# Altium schematic sheet Timer_copy.SchDoc
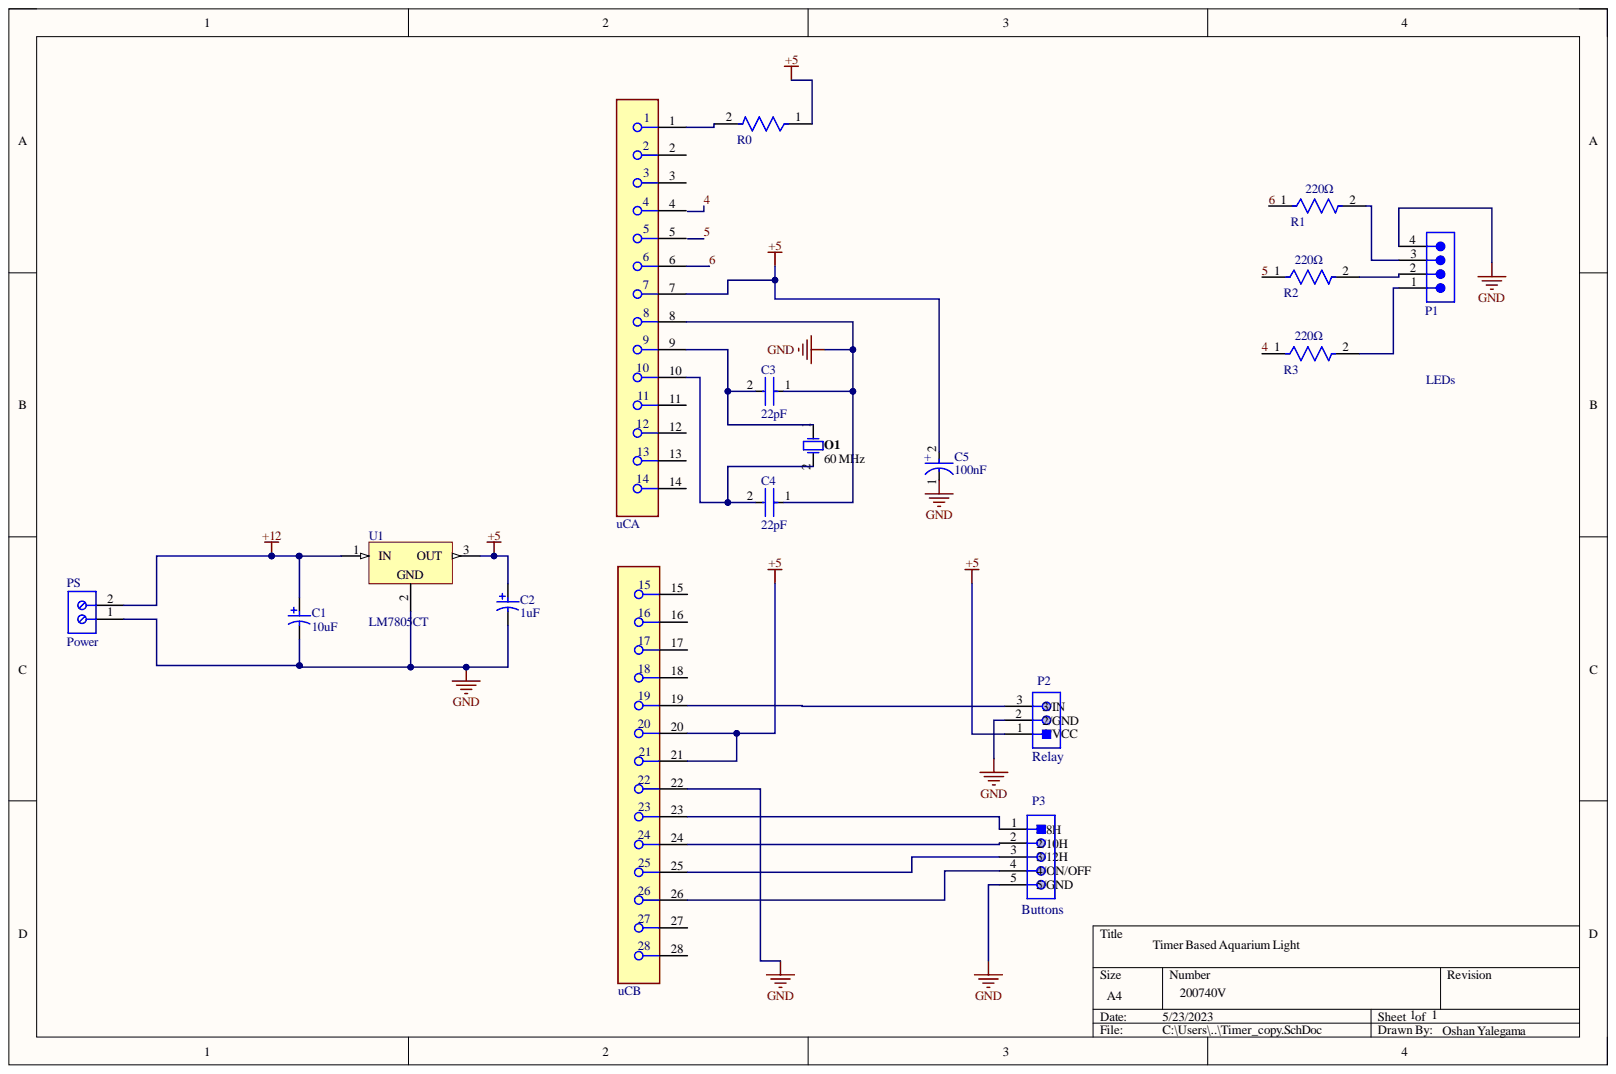
[diagram p0: Timer_copy.SchDoc]

## Parts
C1  10uF  [CAPPR55-200-1100X500X1200]
C2  1uF  [CAPPR55-200-1100X500X1200]
C3  22pF  [FP-RCE0DB-MFG]
C4  22pF  [FP-RCE0DB-MFG]
C5  100nF  [FP-RAD-TH-D_5_0_5-L_11_1-MFG]
O1  60 MHz  [FOX-FOXSLF160-20]
P1  LEDs  [SMTC-TSW-104-08-X-S]
P2  Relay  [61900311121]
P3  Buttons  [61300511121]
PS  Power  [691102710002]
R0  CF18JT2K20  [FP-CF18-MFG]  (value not drawn)
R1  value 220Ω  Resistance: 220Ω  [FP-CF18-MFG]  (hidden comment: CF18JT2K20)
R2  value 220Ω  [FP-CF18-MFG]  (hidden comment: CF18JT2K20)
R3  value 220Ω  [FP-CF18-MFG]  (hidden comment: CF18JT2K20)
U1  LM7805CT  [FAIR-TO-220-3]
uC  1-2199298-9  [FP-1-2199298-9-MFG]  (value not drawn)  (parts A,B)

## Nets
+12: C1.1 PS.2 U1.1
GND: C1.2 C2.2 C3.1 C4.1 C5.1 P1.4 P2.2 P3.5 PS.1 U1.2 uC.8 uC.22
4: R3.1
5: C2.1 C5.2 P2.1 R0.1 R2.1 U1.3 uC.7 uC.20 uC.21
6: R1.1 uC.6
NetC3_2: C3.2 O1.1 uC.9
NetC4_2: C4.2 O1.2 uC.10
NetP1_1: P1.1 R3.2
NetP1_2: P1.2 R2.2
NetP1_3: P1.3 R1.2
NetP2_3: P2.3 uC.19
NetP3_1: P3.1 uC.23
NetP3_2: P3.2 uC.24
NetP3_3: P3.3 uC.25
NetP3_4: P3.4 uC.26
NetR0_2: R0.2 uC.1

Not connected: uC 2 3 4 5 11 12 13 14 15 16 17 18 27 28

## Library data of the parts
C1 = CMP-1665-00038-2: Aluminum Electrolytic Capacitor, 10 uF, +/- 20%, 50 V, -40 to 85 degC, 2-Pin THD, RoHS, Bulk; Manufacturer: Panasonic; Dielectric Material: Aluminium; Dissipation Factor: 0.12%; Lead Diameter: 0.5mm; Lead/Base Style: Straight; Leakage Current: 3uA; Life (Hours): 2000Hour; Ripple Current: 65mA; Voltage: 50V; Capacitance: 10uF; Case/Package: Radial; Component Kind: Standard; Datasheet URL: https://industrial.panasonic.com/cdbs/www-data/pdf/RDF0000/ABA0000C1218.pdf; Datasheet Version: Rev. 05, 10/2016; Diameter: 5mm; Height: 11mm; Height - Seated (Max): 0.472inch; Lead Free: LeadFree; Lead Pitch: 2mm; Length: 11mm; Library Name: Altium Content Vault; Manufacturer URL: http://www.panasonic.com/; Max Operating Temperature: 85degC; Min Operating Temperature: -40degC; Mount: ThroughHole; Number of Pins: 2; Package Description: 2-Pin Radial Lead, Dia 5 mm, Height 12 mm; Package Quantity: 200; Package Reference: CAPPR55-200-1100X500X1200; Packaging: Bulk; Radiation Hardening: No; REACH SVHC: NoSVHC; Ripple Current (AC): 65mA; RoHSCompliant: true; Termination: Radial; Tolerance: 20%; Voltage Rating: 50V; Voltage Rating (DC): 50V
C2 = CMP-1665-00038-2: Aluminum Electrolytic Capacitor, 10 uF, +/- 20%, 50 V, -40 to 85 degC, 2-Pin THD, RoHS, Bulk; Manufacturer: Panasonic; Capacitance: 10uF; Dielectric Material: Aluminium; Dissipation Factor: 0.12%; Lead Diameter: 0.5mm; Lead/Base Style: Straight; Leakage Current: 3uA; Life (Hours): 2000Hour; Ripple Current: 65mA; Voltage: 50V; Case/Package: Radial; Component Kind: Standard; Datasheet URL: https://industrial.panasonic.com/cdbs/www-data/pdf/RDF0000/ABA0000C1218.pdf; Datasheet Version: Rev. 05, 10/2016; Diameter: 5mm; Height: 11mm; Height - Seated (Max): 0.472inch; Lead Free: LeadFree; Lead Pitch: 2mm; Length: 11mm; Library Name: Altium Content Vault; Manufacturer URL: http://www.panasonic.com/; Max Operating Temperature: 85degC; Min Operating Temperature: -40degC; Mount: ThroughHole; Number of Pins: 2; Package Description: 2-Pin Radial Lead, Dia 5 mm, Height 12 mm; Package Quantity: 200; Package Reference: CAPPR55-200-1100X500X1200; Packaging: Bulk; Radiation Hardening: No; REACH SVHC: NoSVHC; Ripple Current (AC): 65mA; RoHSCompliant: true; Termination: Radial; Tolerance: 20%; Voltage Rating: 50V; Voltage Rating (DC): 50V
C3 C4 = CMP-06035-047347-1: Cap Ceramic 22pF 100V C0G ±5% Radial 2.5mm +125°C Ammo Pack; Lead Diameter: 0.5mm; Lead/Base Style: Straight; Capacitance: 22pF; Case/Package: Radial; Component Kind: Standard; Depth: 2.5mm; Dielectric: C0G; Height: 3.5mm; Height - Seated (Max): 0.138inch; Lead Pitch: 0.098inch; Length: 3.6mm; Library Name: Altium Content Vault; Max Operating Temperature: 125°C; Min Operating Temperature: -55°C; Mount: Through Hole; Package Quantity: 2000; Packaging: Tape and Reel; Ratings: AEC-Q200; Resistor Type: Ceramic; Termination: Radial; Tolerance: 5%; Voltage: 100V; Voltage Rating: 100V; Voltage Rating (DC): 100V; Width: 0.098inch
C5 = CMP-05427-001672-1: Aluminum Electrolytic Capacitors (Radial Lead Type) 68uF ±20% 16V; ESR (Equivalent Series Resistance): 300mR; Lead Diameter: 0.5mm; Life (Hours): 5000Hour; Polarity: Polar; Ripple Current: 280mA; Capacitance: 68uF; Case/Package: Radial; Component Kind: Standard; Diameter: 5mm; Features: General Purpose; Height: 11mm; Height - Seated (Max): 0.433inch; Impedance: 300mR; Lead Pitch: 5mm; Length: 11mm; Library Name: Altium Content Vault; Max Operating Temperature: 105°C; Min Operating Temperature: -40°C; Mount: Through Hole; Package Quantity: 2000; Packaging: Tape and Reel; Radiation Hardening: No; REACH SVHC: No SVHC; RoHS Compliant: Yes; Series: FR; Termination: Radial; Tolerance: 20%; Voltage Rating: 16V; Voltage Rating (DC): 16V
O1 = CMP-1749-00003-1: Resistance Weld Thru-Hole Crystal, 16 MHz, -20 to 70 degC, 2-Pin THD, RoHS, Bulk; Case\Package: Axial; Power Rating: 250 mW; Resistance: 221 kohm; Tolerance: 1%; Voltage Rating: 250 V; Component Kind: Standard; ComponentLink1Description: Manufacturer URL; ComponentLink1URL: http://www.foxonline.com/; ComponentLink2Description: Datasheet; ComponentLink2URL: http://www.foxonline.com/pdfs/hc49slf.pdf; DatasheetVersion: Mar-15; Length: 0.248 inch; Library Name: Altium Content Vault; Max Operating Temperature: 155 degC; Min Operating Temperature: -55 degC; Mount: Through Hole; Package Quantity: 200; PackageDescription: 2-Pin Through Hole Device, Body 11.35 x 4.65 mm; PackageReference: FOXSLF160-20; Packaging: Bulk; RoHSCompliant: true
P1 = CMP-1024-00357-1: 0.025" SQ Post Header, Through-hole, Vertical, -55 to 125 degC, 2.54 mm Pitch, 4-Pin, Male, RoHS; Manufacturer: Samtec; PartNumber: TSW-104-08-G-S; Pitch: 2.54 mm; Component Kind: Standard; ComponentLink1Description: Manufacturer URL; ComponentLink1URL: http://www.samtec.com; ComponentLink2Description: Datasheet; ComponentLink2URL: http://www.samtec.com/documents/webfiles/pdf/TSW_TH.PDF; ComponentLink3Description: Package Specification; ComponentLink3URL: http://www.samtec.com/documents/webfiles/cpdf/TSW-XXX-XX-XXX-X-XX-XXX-MKT.pdf; DatasheetVersion: F-214; Library Name: Altium Content Vault; Mounting Technology: Through-hole; Orientation: Vertical; PackageDescription: .025[0.64] SQ. Post Terminal Strip Assembly; PackageReference: TSW-104-08-X-S; PackageVersion: DM, 8/1988; RoHS: True; Temperature Range: -55 to 125 degC
P2 = CMP-1502-00585-1: Male Locking Header WR-WTB, THT, Vertical, pitch 2.54 mm, 1 x 3 position; Manufacturer: Wurth Elektronik; Contact Resistance: 20mOhm; Pitch: 2.54mm; Position: 1x3; Working Voltage: 250VAC; Component Kind: Standard; Datasheet URL: https://katalog.we-online.de/em/datasheet/6190xx11121.pdf; Gender: Male; Library Name: Altium Content Vault; Manufacturer URL: http://www.we-online.de; Mounting Technology: THT; Operating Temperature: -40to105degC; Orientation: Vertical; Package Reference: 61900311121; Packaging: Bag; Part Number: 61900311121; Publisher: WurthElektronik
P3 = CMP-1502-01068-1: THT Vertical Pin Header WR-PHD, Pitch 2.54 mm, Single Row, 5 pins; Manufacturer: Wurth Elektronik; Contact Resistance: 20mOhm; Gender: Male; Pin Number: 5; Pitch: 2.54mm; Rated Current: 3A; Withstanding Voltage: 500VAC; Working Voltage: 250VAC; Component Kind: Standard; Datasheet URL: https://katalog.we-online.de/em/datasheet/6130xx11121.pdf; Library Name: Altium Content Vault; Manufacturer URL: http://www.we-online.de; Mounting Technology: THT; Operating Temperature: -40to105degC; Orientation: Vertical; Package Reference: 61300511121; Packaging: Bag; Part Number: 61300511121; Row Number: Single; Publisher: WurthElektronik
PS = CMP-1502-03258-1: Series 102 - 5.00 mm Horizontal Entry Modular with Pressure Clamp WR-TBL, 2 pin; Manufacturer: Wurth Elektronik; Pin Number: 2; Pitch: 5.00mm; Component Kind: Standard; Datasheet URL: http://katalog.we-online.de/em/datasheet/6911027100xx.pdf; Library Name: Altium Content Vault; Manufacturer URL: http://www.we-online.de; Mounting Technology: THT; Operating Temperature: -40to105degC; Package Reference: 691102710002; Packaging: Box; Part Number: 691102710002; Publisher: WurthElektronik
R0 = CMP-26528-000001-1: RES 2.2K OHM 1/8W 5% CF AXIAL; Manufacturer: Stackpole Electronics Inc; Power Rating: 0.125W; Resistance: 2.2kΩ; Temperature Coefficient: 0/-400ppm/°C; Value: 2.2kΩ; Voltage Rating: 250V; Automotive: No; Category: Res; Component Kind: Standard; ComponentLink2Description: Datasheet; ComponentLink2URL: https://www.seielect.com/catalog/sei-cf_cfm.pdf; Composition: Carbon Film; Device Class L1: Passive Components; Device Class L2: Resistors; Device Class L3: Through-Hole Resistors; Digikey Description: RES 2.2K OHM 1/8W 5% CF AXIAL; Height: 2mm; Ipc Land Pattern Name: RESAD656W65L360D200; Lead Free: Yes; Library Name: Altium Content Vault; Manufacturer Part Number: CF18JT2K20; Octopart: https://octopart.com/cf18jt2k20-stackpole+electronics-19205714; Package: CF18; RoHS: TRUE; Series: CF; Temperature: -55°C to +155°C; Temperature Range High: +155°C; Temperature Range Low: -55°C; Tolerance: 5%
R1 = CMP-26528-000001-1: RES 2.2K OHM 1/8W 5% CF AXIAL; Manufacturer: Stackpole Electronics Inc; Power Rating: 0.125W; Temperature Coefficient: 0/-400ppm/°C; Voltage Rating: 250V; Automotive: No; Category: Res; Component Kind: Standard; ComponentLink2Description: Datasheet; ComponentLink2URL: https://www.seielect.com/catalog/sei-cf_cfm.pdf; Composition: Carbon Film; Device Class L1: Passive Components; Device Class L2: Resistors; Device Class L3: Through-Hole Resistors; Digikey Description: RES 2.2K OHM 1/8W 5% CF AXIAL; Height: 2mm; Ipc Land Pattern Name: RESAD656W65L360D200; Lead Free: Yes; Library Name: Altium Content Vault; Manufacturer Part Number: CF18JT2K20; Octopart: https://octopart.com/cf18jt2k20-stackpole+electronics-19205714; Package: CF18; RoHS: TRUE; Series: CF; Temperature: -55°C to +155°C; Temperature Range High: +155°C; Temperature Range Low: -55°C; Tolerance: 5%
R2 R3 = CMP-26528-000001-1: RES 2.2K OHM 1/8W 5% CF AXIAL; Manufacturer: Stackpole Electronics Inc; Power Rating: 0.125W; Resistance: 2.2kΩ; Temperature Coefficient: 0/-400ppm/°C; Voltage Rating: 250V; Automotive: No; Category: Res; Component Kind: Standard; ComponentLink2Description: Datasheet; ComponentLink2URL: https://www.seielect.com/catalog/sei-cf_cfm.pdf; Composition: Carbon Film; Device Class L1: Passive Components; Device Class L2: Resistors; Device Class L3: Through-Hole Resistors; Digikey Description: RES 2.2K OHM 1/8W 5% CF AXIAL; Height: 2mm; Ipc Land Pattern Name: RESAD656W65L360D200; Lead Free: Yes; Library Name: Altium Content Vault; Manufacturer Part Number: CF18JT2K20; Octopart: https://octopart.com/cf18jt2k20-stackpole+electronics-19205714; Package: CF18; RoHS: TRUE; Series: CF; Temperature: -55°C to +155°C; Temperature Range High: +155°C; Temperature Range Low: -55°C; Tolerance: 5%
U1 = CMP-2000-04938-1: Positive Voltage Regulator, 5 V, 1 A, -40 to 125 degC, 3-Pin TO-220, RoHS, Tube; Manufacturer: FairchildSemiconductor; Accuracy: ±4%; Line Regulation: 100mV; Maximum Input Voltage: 35V; Maximum Output Current: 2.2(Typ)A; Maximum Quiescent Current: 8mA; Number of Outputs: 1; Output Type: Fixed; Output Voltage: 5V; Rad Hard: No; Typical Dropout Voltage @ Current: 2@1AV; Category: LinearRegulator; Component Kind: Standard; Datasheet URL: https://datasheet.ciiva.com/26904/lm7805ct-26904988.pdf; Datasheet Version: Aug-12; Library Name: Altium Content Vault; Load Regulation: 100mV; Manufacturer URL: https://www.fairchildsemi.com/; Mounting: ThroughHole; Operating Temperature: -40to125degC; Package: 3TO-220AB; Package Description: 3-Pin Through Hole Device, Body 10 x 4.5 mm, Pitch 2.54 mm; Package Reference: TO-220; Package URL: https://www.fairchildsemi.com/package-drawings/TO/TO220B03.pdf; Packaging: Rail/Tube; Polarity: Positive; Type: Linear
uC = CMP-03370-000006-1: CONN IC DIP SOCKET 28POS TIN; Connector Type: Pin; Contact Current Rating: 1A; Contact Post Length: 3inch; Insulation Resistance: 1GR; Mating Alignment: Yes (With); Number of Contacts: 28; Pitch: 2.54mm; Row Spacing: 0.3inch; Color: Black; Component Kind: Standard; Contact Material: Bronze; Contact Plating: Tin; Current Rating: 1A; ELV: Compliant; Flammability Rating: UL94 V-0; Housing Material: PBT; Insulation Material: Thermoplastic; Length: 1.4inch; Library Name: Altium Content Vault; Max Operating Temperature: 105°C; Min Operating Temperature: -40°C; Mount: Through Hole; Number of Poles: 28; Number of Positions: 28; Number of Rows: 2; Orientation: Straight; Package Quantity: 17; Packaging: Rail/Tube; Radiation Hardening: No; RoHS Compliant: Yes; Termination: Through Hole
